# Altium schematic sheet Sheet1_alkot.SchDoc(Sheet1_alkot)
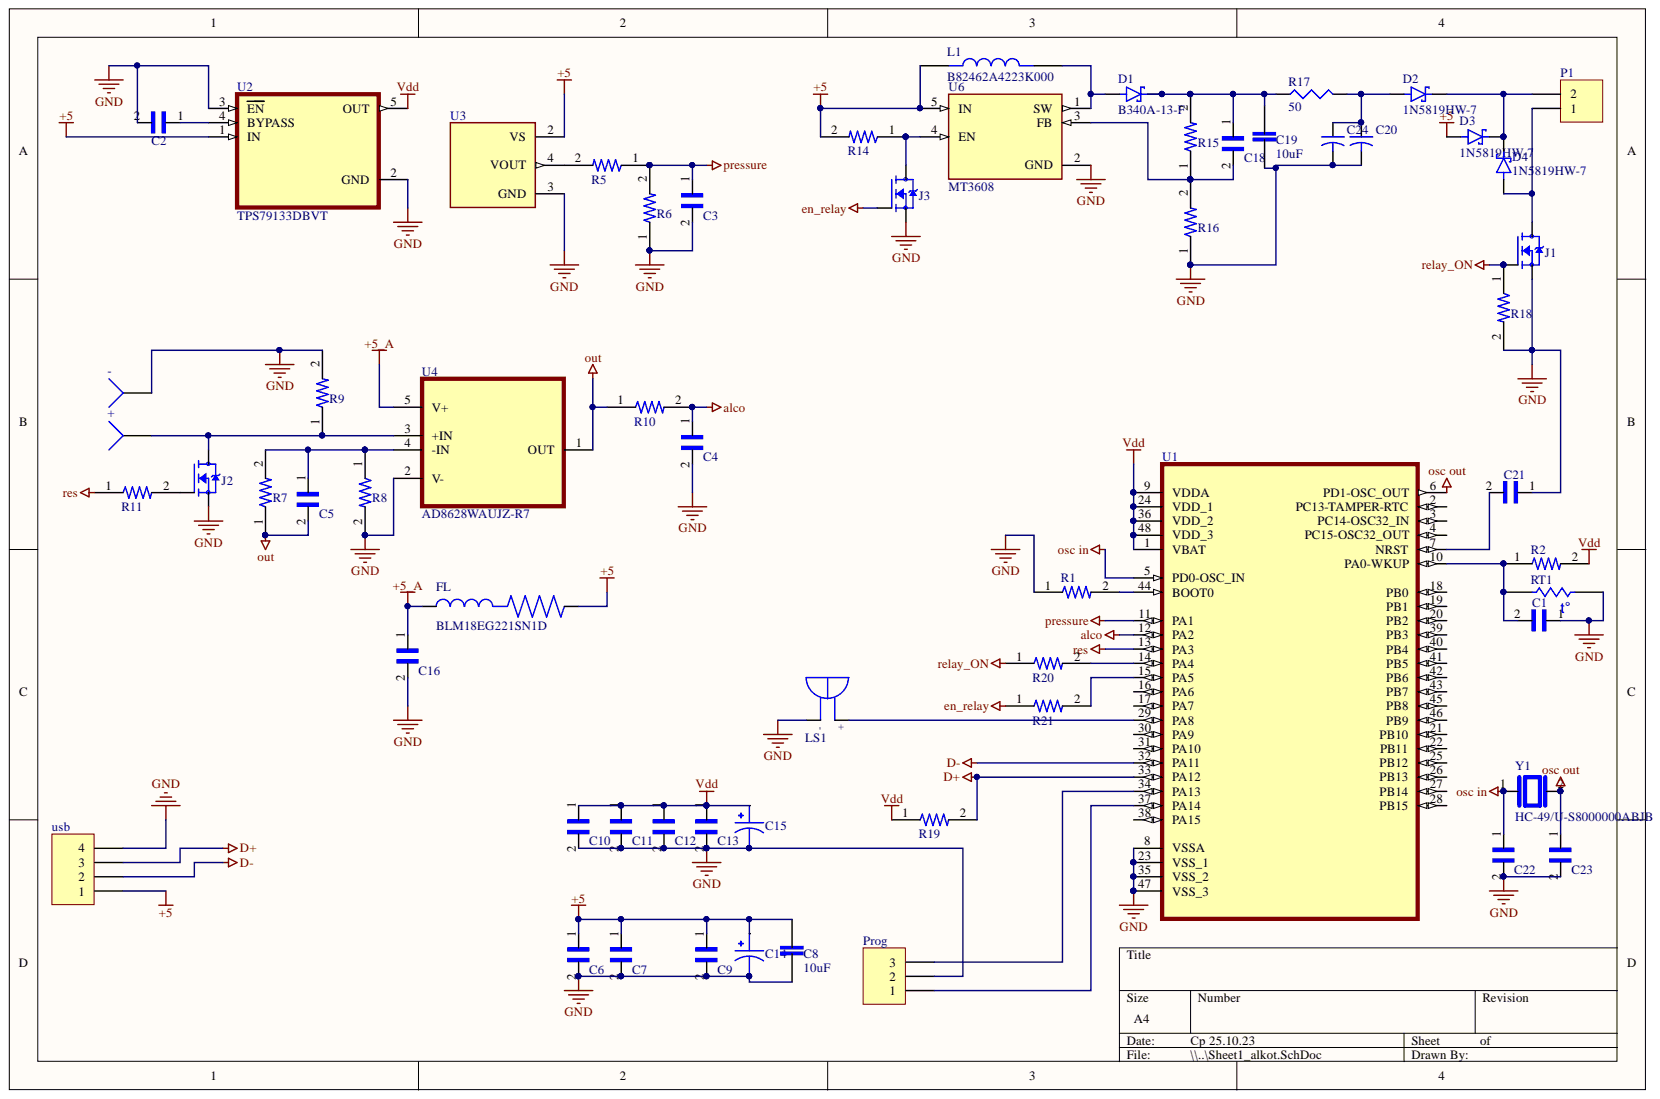
[diagram p0: Sheet1_alkot.SchDoc]

## Parts
+  Socket  [PIN1]  (value not drawn)
-  Socket  [PIN1]  (value not drawn)
C1  0.1uF  [CAPC1608X90]  (value not drawn)
C2  0.1 uF  [CAPC1608X90]  (value not drawn)
C3  0.1uF  [CAPC1608X90]  (value not drawn)
C4  0.1uF  [CAPC1608X90]  (value not drawn)
C5  0.1uF  [CAPC1608X90]  (value not drawn)
C6  0.1uF  [CAPC1608X90]  (value not drawn)
C7  0.1uF  [CAPC1608X90]  (value not drawn)
C8  10uF  [CAPC2012X140N]
C9  0.1uF  [CAPC1608X90]  (value not drawn)
C10  0.1uF  [CAPC1608X90]  (value not drawn)
C11  0.1uF  [CAPC1608X90]  (value not drawn)
C12  0.1uF  [CAPC1608X90]  (value not drawn)
C13  0.1uF  [CAPC1608X90]  (value not drawn)
C14  TPSD107M010R0100  [CAPPM7343X310N]  (value not drawn)
C15  TPSD107M010R0100  [CAPPM7343X310N]  (value not drawn)
C16  22uF  [CAPC1608X90]  (value not drawn)
C18  1nF  [CAPC1608X90]  (value not drawn)
C19  10uF  [CAPC2012X140N]
C20  470uF25v  [CAPR5-4X5]  (value not drawn)
C21  0.1uF  [CAPC1608X90]  (value not drawn)
C22  33pF  [CAPC1608X90]  (value not drawn)
C23  33pF  [CAPC1608X90]  (value not drawn)
C24  470uF25v  [CAPR5-4X5]  (value not drawn)
D1  B340A-13-F  [DIOM5226X240N]
D2  1N5819HW-7  [SOD3715X145N]
D3  1N5819HW-7  [SOD3715X145N]
D4  1N5819HW-7  [SOD3715X145N]
FL  BLM18EG221SN1D  [BEADC1608X95N]
J1  IRLML2502  [SOT23]  (value not drawn)
J2  IRLML2502  [SOT23]  (value not drawn)
J3  IRLML2502  [SOT23]  (value not drawn)
L1  B82462A4223K000  [IND_B82462A4473K000]
LS1  KXG0903C1  [XDCR_KXG0903C1]  (value not drawn)
P1  reley  [HDR1X2]  (value not drawn)
Prog  Header 3  [HDR1X3]  (value not drawn)
R1  10k  [RESC1608X50N]  (value not drawn)
R2  10k  [RESC1608X50N]  (value not drawn)
R5  20k  [RESC1608X50N]  (value not drawn)
R6  20k  [RESC1608X50N]  (value not drawn)
R7  200k  [RESC1608X50N]  (value not drawn)
R8  100  [RESC1608X50N]  (value not drawn)
R9  10  [RESC1608X50N]  (value not drawn)
R10  1k  [RESC1608X50N]  (value not drawn)
R11  330  [RESC1608X50N]  (value not drawn)
R14  1k  [RESC1608X50N]  (value not drawn)
R15  100k  [RESC1608X50N]  (value not drawn)
R16  4,7k  [RESC1608X50N]  (value not drawn)
R17  50  [12Z-2010]
R18  10k  [RESC1608X50N]  (value not drawn)
R19  1k  [RESC1608X50N]  (value not drawn)
R20  10  [RESC1608X50N]  (value not drawn)
R21  10  [RESC1608X50N]  (value not drawn)
RT1  10 k (NCP21XV103)  [6-0805_N]  (value not drawn)
U1  STM32F100C8T6B  [QFP50P900X900X160-48N]  (value not drawn)
U2  TPS79133DBVT  [SOT95P280X145-5N]
U3  MPXV7002DP  [XDCR_MPXV7002DP]  (value not drawn)
U4  AD8628WAUJZ-R7  [SOT95P280X100-5N]
U6  MT3608  [SOT95P280X145-6N]
usb  Header 4  [HDR1X4]  (value not drawn)
Y1  HC-49/U-S8000000ABJB  [XTAL_HC49P488W43L1150T466H350]

## Nets
+5: C6.1 C7.1 C8.2 C9.1 C14.1 D3.A FL.2 L1.1 R14.2 U2.1(IN) U3.2 U6.5(IN) usb.1
+5_A: C16.1 FL.1 U4.5(V+)
alco: C4.1 R10.2 U1.12(PA2)
D+: R19.2 U1.33(PA12) usb.3
D-: U1.32(PA11) usb.2
en_relay: J3.1 R21.1
GND: -.1 C1.1 C2.2 C3.2 C4.2 C6.2 C7.2 C8.1 C9.2 C10.2 C11.2 C12.2 C13.2 C14.2 C15.2 C16.2 C19.1 C20.2 C21.1 C22.2 C23.2 C24.2 J1.2 J2.2 J3.2 LS1.N Prog.2 R1.1 R6.1 R8.2 R9.2 R16.1 R18.2 RT1.1 U1.8(VSSA) U1.23(VSS_1) U1.35(VSS_2) U1.47(VSS_3) U2.2(GND) U2.3(EN) U3.3 U4.2(V-) U6.2(GND) usb.4
osc in: C22.1 U1.5(PD0-OSC_IN) Y1.1
osc out: C23.1 U1.6(PD1-OSC_OUT) Y1.2
out: C5.2 R7.1 R10.1 U4.1(OUT)
pressure: C3.1 R5.1 R6.2 U1.11(PA1)
relay_ON: J1.1 R18.1 R20.1
res: R11.1 U1.13(PA3)
Vdd: C10.1 C11.1 C12.1 C13.1 C15.1 R2.2 R19.1 U1.1(VBAT) U1.9(VDDA) U1.24(VDD_1) U1.36(VDD_2) U1.48(VDD_3) U2.5(OUT)
Net+_1: +.1 J2.3 R9.1 U4.3(+IN)
NetC1_2: C1.2 R2.1 RT1.2 U1.10(PA0-WKUP)
NetC2_1: C2.1 U2.4(BYPASS)
NetC5_1: C5.1 R7.2 R8.1 U4.4(-IN)
NetC18_1: C18.1 C19.2 D1.C R15.2 R17.1
NetC18_2: C18.2 R15.1 R16.2 U6.3(FB)
NetC20_1: C20.1 C24.1 D2.A R17.2
NetC21_2: C21.2 U1.7(NRST)
NetD1_A: D1.A L1.2 U6.1(SW)
NetD2_C: D2.C D3.C D4.C P1.2
NetD4_A: D4.A J1.3 P1.1
NetJ2_1: J2.1 R11.2
NetJ3_3: J3.3 R14.1 U6.4(EN)
NetLS1_P: LS1.P U1.29(PA8)
NetProg_1: Prog.1 U1.37(PA14)
NetProg_3: Prog.3 U1.34(PA13)
NetR1_2: R1.2 U1.44(BOOT0)
NetR5_2: R5.2 U3.4
NetR20_2: R20.2 U1.14(PA4)
NetR21_2: R21.2 U1.15(PA5)

Not connected: U1 2(PC13-TAMPER-RTC) 3(PC14-OSC32_IN) 4(PC15-OSC32_OUT) 16(PA6) 17(PA7) 18(PB0) 19(PB1) 20(PB2) 21(PB10) 22(PB11) 25(PB12) 26(PB13) 27(PB14) 28(PB15) 30(PA9) 31(PA10) 38(PA15) 39(PB3) 40(PB4) 41(PB5) 42(PB6) 43(PB7) 45(PB8) 46(PB9)

## Library data of the parts
C1 C4-C7 C9-C13 C16 C21 = LD03ZD475MAB2A: COMMERCIAL CHIP STD JAPAN BULK - FN TAPE/REEL, 7 INCH PAPER TAPE; MF: {'manufacturer': u'AVX'}; Value: 0.1 mKf; Availability: Unavailable; Component Kind: Standard; Library Name: SnapEDA_Library.IntLib; MP: LD03ZD475MAB2A; Package: None; Price: None
C2 = LD03ZD475MAB2A: COMMERCIAL CHIP STD JAPAN BULK - FN TAPE/REEL, 7 INCH PAPER TAPE; MF: {'manufacturer': u'AVX'}; Value: 0.1uF; Availability: Unavailable; Component Kind: Standard; Library Name: SnapEDA_Library.IntLib; MP: LD03ZD475MAB2A; Package: None; Price: None
C3 C18 C22 C23 = LD03ZD475MAB2A: COMMERCIAL CHIP STD JAPAN BULK - FN TAPE/REEL, 7 INCH PAPER TAPE; MF: {'manufacturer': u'AVX'}; Availability: Unavailable; Component Kind: Standard; Library Name: SnapEDA_Library.IntLib; MP: LD03ZD475MAB2A; Package: None; Price: None
  C3: Value: 0.1uF
  C18: Value: 1nF
  C22 C23: Value: 33pF
C8 C19 = LD051A390KAB2A: Cap Ceramic 39pF 100V C0G 10% SMD 0805 125В°C Paper T/R; MF: {'manufacturer': u'AVX'}; Availability: Unavailable; Component Kind: Standard; Library Name: SnapEDA_Library.IntLib; MP: LD051A390KAB2A; Package: None; Price: None
C14 C15 = TPSD107M010R0100: MF: {'manufacturer': u'AVX'}; Value: 100x10v; Availability: Unavailable; Component Kind: Standard; Library Name: SnapEDA_Library.IntLib; MP: TPSD107M010R0100; Package: None; Price: None
C20 C24 = Cap2: Capacitor; Value: 470uF; Component Kind: Standard; Library Name: Miscellaneous Devices.IntLib; PackageDescription: Capacitor; 2 Leads; PackageReference: CAPR5-4X5; Published: 23-Sep-2002; Signal Integrity: Cap; Simulation: CAP; Publisher: Altium Limited
D1 = B340A-13-F: Diode, Rectifier, 3a, 40v, Do-214ac, Full Reel; MF: {'manufacturer': u'Diodes Inc.'}; Availability: Unavailable; Component Kind: Standard; Library Name: SnapEDA_Library.IntLib; MP: B340A-13-F; Package: None; Price: None
D2-D4 = 1N5819HW-7: 1N5819HW Series 40 V 1 A Surface Mount Schottky Barrier Rectifier - SOD-123; MF: {'manufacturer': u'Diodes Inc.'}; Availability: Unavailable; Component Kind: Standard; Library Name: SnapEDA_Library.IntLib; MP: 1N5819HW-7; Package: None; Price: None
FL = BLM18EG221SN1D: 220 Ohms @ 100MHz 1 Ferrite Bead 0603 _1608 Metric_ 2A 50mOhm; MF: Murata; Availability: Unavailable; Component Kind: Standard; Library Name: BLM18EG221SN1D.IntLib; MP: BLM18EG221SN1D; Package: 1608 Murata; Price: None; SnapEDA_Link: https://www.snapeda.com/parts/BLM18EG221SN1D/Murata/view-part/248756/?ref=snap
J1-J3 = IRLML2502: MF: {'manufacturer': u'Infineon Technologies'}; Availability: Unavailable; Component Kind: Standard; Library Name: SnapEDA_Library.IntLib; MP: IRLML2502; Package: None; Price: None
L1 = B82462A4473K000: MF: {'manufacturer': u'TDK Electronics'}; Availability: Unavailable; Component Kind: Standard; Library Name: SnapEDA_Library.IntLib; MP: B82462A4473K000; Package: None; Price: None
LS1 = KXG0903C1: MF: {'manufacturer': u'Kingstate'}; Availability: Unavailable; Component Kind: Standard; Library Name: SnapEDA_Library.IntLib; MP: KXG0903C1; Package: None; Price: None
P1 = Header 2: Header, 2-Pin; Component Kind: Standard; LatestRevisionDate: 17-Jul-2002; LatestRevisionNote: Re-released for DXP Platform.; Library Name: Miscellaneous Connectors.IntLib; Signal Integrity: Connector; Publisher: Altium Limited
Prog = Header 3: Header, 3-Pin; Component Kind: Standard; LatestRevisionDate: 17-Jul-2002; LatestRevisionNote: Re-released for DXP Platform.; Library Name: Miscellaneous Connectors.IntLib; Signal Integrity: Connector; Publisher: Altium Limited
R1 R2 R18 = 1622830-1: CRG0603 1% 10K; MF: {'manufacturer': u'TE Connectivity'}; Availability: Unavailable; Component Kind: Standard; Library Name: SnapEDA_Library.IntLib; MP: 1622830-1; Package: None; Price: None; Value: 10k
R5 R6 = 1622830-1: CRG0603 1% 20k; MF: {'manufacturer': u'TE Connectivity'}; Availability: Unavailable; Component Kind: Standard; Library Name: SnapEDA_Library.IntLib; MP: 1622830-1; Package: None; Price: None; Value: 20k
R7 = 1622830-1: CRG0603 1% 50k; MF: {'manufacturer': u'TE Connectivity'}; Availability: Unavailable; Component Kind: Standard; Library Name: SnapEDA_Library.IntLib; MP: 1622830-1; Package: None; Price: None; Value: 50k
R8 = 1622830-1: CRG0603 1% 100; MF: {'manufacturer': u'TE Connectivity'}; Availability: Unavailable; Component Kind: Standard; Library Name: SnapEDA_Library.IntLib; MP: 1622830-1; Package: None; Price: None; Value: 100
R9 R20 R21 = 1622830-1: CRG0603 1% 10; MF: {'manufacturer': u'TE Connectivity'}; Availability: Unavailable; Component Kind: Standard; Library Name: SnapEDA_Library.IntLib; MP: 1622830-1; Package: None; Price: None; Value: 10
R10 R19 = 1622830-1: CRG0603 1% 1k; MF: {'manufacturer': u'TE Connectivity'}; Availability: Unavailable; Component Kind: Standard; Library Name: SnapEDA_Library.IntLib; MP: 1622830-1; Package: None; Price: None; Value: 1k
R11 = 1622830-1: CRG0603 1% 330; MF: {'manufacturer': u'TE Connectivity'}; Availability: Unavailable; Component Kind: Standard; Library Name: SnapEDA_Library.IntLib; MP: 1622830-1; Package: None; Price: None; Value: 330
R14 = 1622830-1: CRG0603 1% 1K; MF: {'manufacturer': u'TE Connectivity'}; Availability: Unavailable; Component Kind: Standard; Library Name: SnapEDA_Library.IntLib; MP: 1622830-1; Package: None; Price: None; Value: 1k
R15 = 1622830-1: CRG0603 1% 27k; MF: {'manufacturer': u'TE Connectivity'}; Availability: Unavailable; Component Kind: Standard; Library Name: SnapEDA_Library.IntLib; MP: 1622830-1; Package: None; Price: None; Value: 27k
R16 = 1622830-1: CRG0603 1% 2.2k; MF: {'manufacturer': u'TE Connectivity'}; Availability: Unavailable; Component Kind: Standard; Library Name: SnapEDA_Library.IntLib; MP: 1622830-1; Package: None; Price: None; Value: 2.2k
R17 = Res3: Resistor; Component Kind: Standard; LatestRevisionDate: 29-May-2009; LatestRevisionNote: IPC-7351 Footprint Added.; Library Name: Miscellaneous Devices.IntLib; PackageDescription: Chip Resistor; PackageReference: J1-0603; Published: 8-Jun-2000; Signal Integrity: Res; Simulation: RESISTOR; Value: 50; Publisher: Altium Limited
RT1 = Res Thermal: Thermistor; Code_IPC: RESC2012; Component Kind: Standard; LatestRevisionDate: 29-May-2009; LatestRevisionNote: IPC-7351 Footprint Added.; Library Name: Miscellaneous Devices.IntLib; PackageDescription: Chip Resistor 0805 Case Size; PackageReference: 6-0805; PackageVersion: Mar-2003; Published: 24-Mar-1999; Publisher: Altium Limited
U1 = STM32F100C8T6B: ARM-based 32-bit MCU; MF: {'manufacturer': u'STMicroelectronics'}; Availability: Unavailable; Component Kind: Standard; Library Name: SnapEDA_Library.IntLib; MP: STM32F100C8T6B; Package: None; Price: None; Value: stm32f100
U2 = TPS79133DBVT: MF: {'manufacturer': u'Texas Instruments'}; Availability: Unavailable; Component Kind: Standard; Library Name: SnapEDA_Library.IntLib; MP: TPS79133DBVT; Package: None; Price: None; Value: tps79133
U3 = MPXV7002DP: Pressure Sensor В±0.29PSI (В±2kPa) Differential Male - 0.13" (3.3mm) Tube, Dual 0.5 V ~ 4.5 V 8-BSOP (0.475", 12.06mm Width) Dual Ports, Same Side; MF: {'manufacturer': u'NXP USA'}; Value: mpx7002; Availability: Unavailable; Component Kind: Standard; Library Name: SnapEDA_Library.IntLib; MP: MPXV7002DP; Package: None; Price: None
U4 = AD8628WAUJZ-R7: Zero-Drift, Single-Supply, RRIO Op Amp; MF: {'manufacturer': u'Analog Devices'}; Availability: Unavailable; Component Kind: Standard; Library Name: SnapEDA_Library.IntLib; MP: AD8628WAUJZ-R7; Package: None; Price: None
U6 = MT3608: High Efficiency 1.2MHz 2A Step Up Converter; MF: {'manufacturer': u'Aerosemi Technology Co., Ltd'}; Availability: Unavailable; Component Kind: Standard; Library Name: SnapEDA_Library.IntLib; MP: MT3608; Package: None; Price: None
usb = Header 4: Header, 4-Pin; Component Kind: Standard; LatestRevisionDate: 17-Jul-2002; LatestRevisionNote: Re-released for DXP Platform.; Library Name: Miscellaneous Connectors.IntLib; Signal Integrity: Connector; Publisher: Altium Limited
Y1 = HC-49/U-S16000000ABJB: HC49US Series 16 MHz В±30 ppm 18 pF -20 to +70 В°C Through Hole AT-CUT Crystal; MF: {'manufacturer': u'Citizen Finedevice Co Ltd'}; Availability: Unavailable; Component Kind: Standard; Library Name: SnapEDA_Library.IntLib; MP: HC-49/U-S8000000ABJB; Package: None; Price: None
+ - = Socket: Component Kind: Standard; Description: Socket; LatestRevisionDate: 17-Jul-2002; LatestRevisionNote: Re-released for DXP Platform.; Library Name: Miscellaneous Connectors.IntLib; Signal Integrity: Connector; Publisher: Altium Limited
